# Altium schematic sheet MCU_EFM32.SchDoc
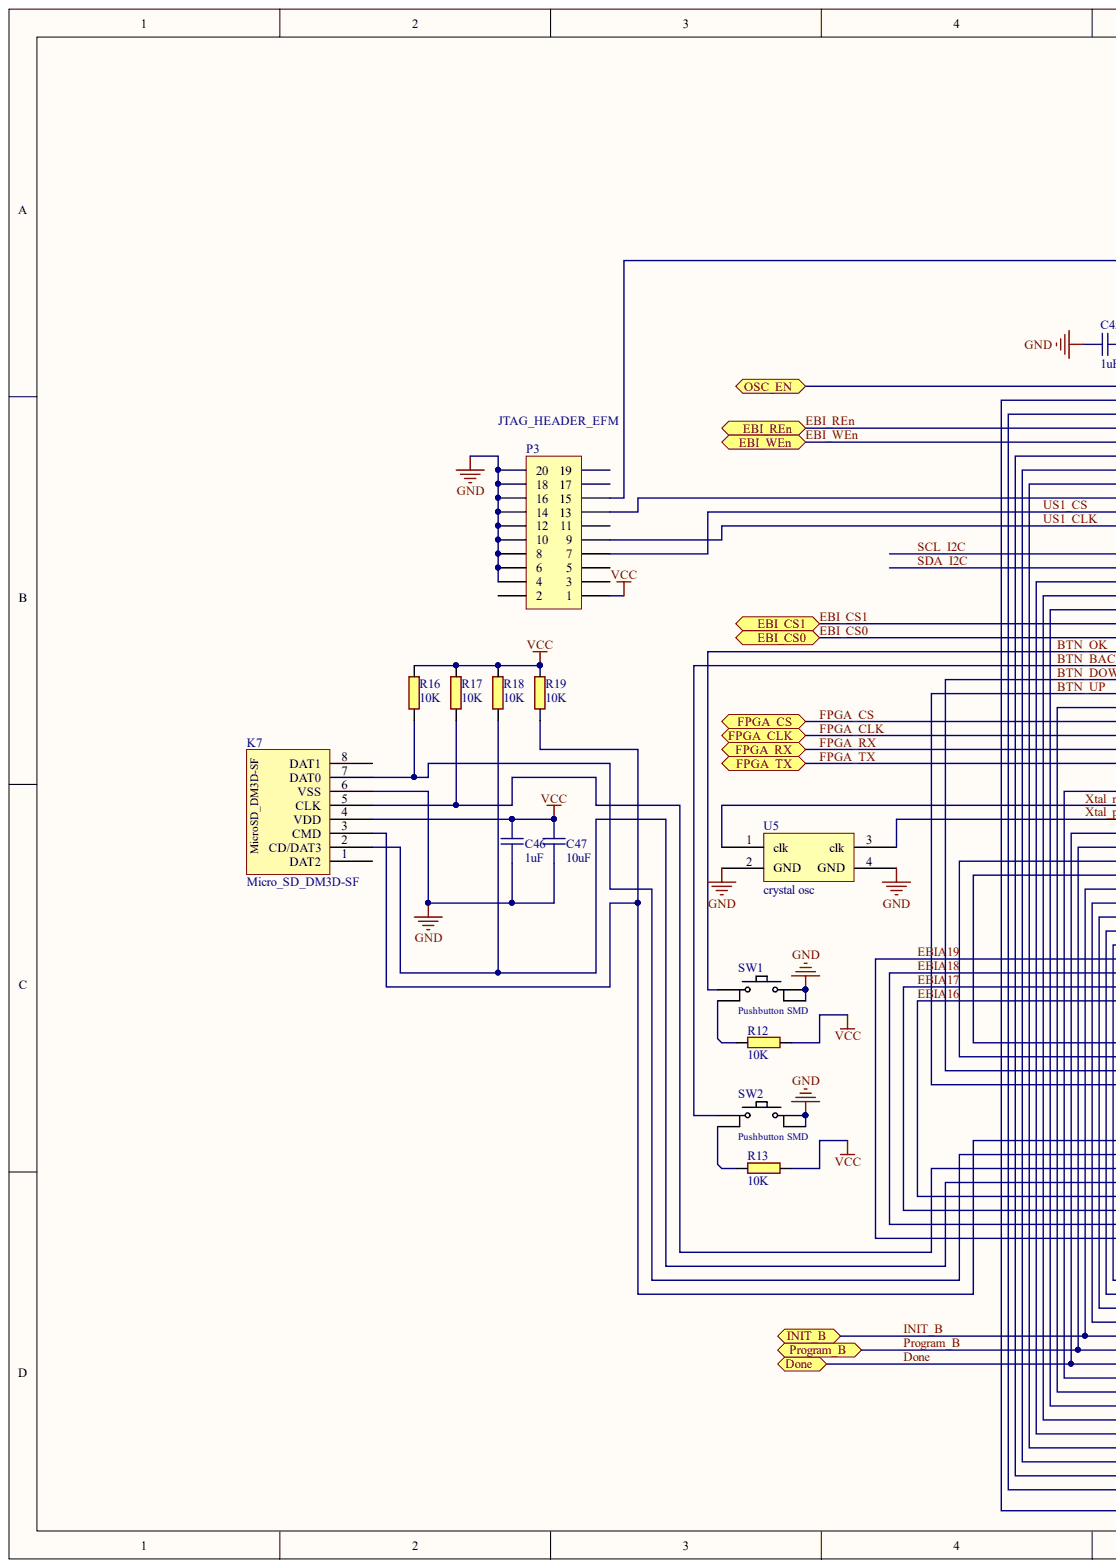
[diagram p0: MCU_EFM32.SchDoc, left]
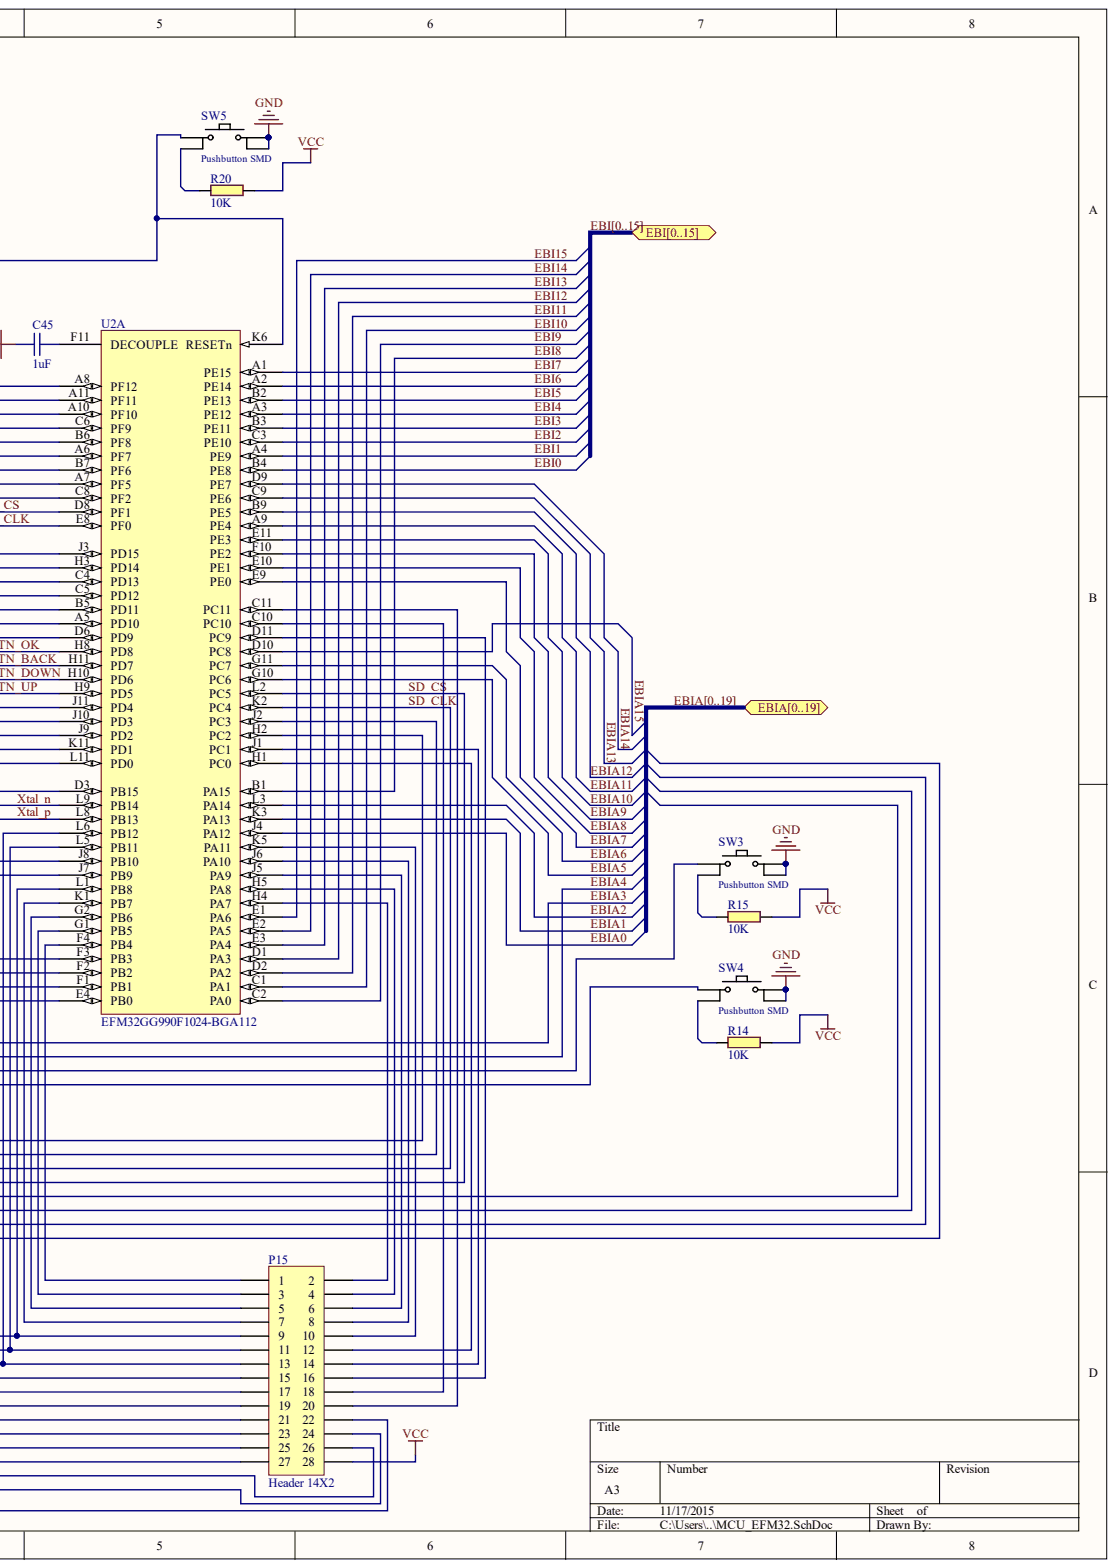
[diagram p1: MCU_EFM32.SchDoc, right]

## Parts
C45  1uF  [CAPC0603(1608)55_N]
C46  1uF  [CAPC0603(1608)55_N]
C47  10uF  [CAPC0603(1608)55_N]
K7  Micro_SD_DM3D-SF  [DM3D-SF]
P3  JTAG_HEADER_EFM  [HDR2X10]
P15  Header 14X2  [HDR2X14]
R12  10K  [RESC0603(1608)_N]
R13  10K  [RESC0603(1608)_N]
R14  10K  [RESC0603(1608)_N]
R15  10K  [RESC0603(1608)_N]
R16  10K  [RESC0603(1608)_N]
R17  10K  [RESC0603(1608)_N]
R18  10K  [RESC0603(1608)_N]
R19  10K  [RESC0603(1608)_N]
R20  10K  [RESC0603(1608)_N]
SW1  Pushbutton SMD  [SOIC254P500X160-4]
SW2  Pushbutton SMD  [SOIC254P500X160-4]
SW3  Pushbutton SMD  [SOIC254P500X160-4]
SW4  Pushbutton SMD  [SOIC254P500X160-4]
SW5  Pushbutton SMD  [SOIC254P500X160-4]
U2  EFM32GG990F1024-BGA112  [RESC0603(1608)_N]  (part A)
U5  crystal osc  [Crystall oscillator]

## Ports
DONE, EBI0, EBI1, EBI2, EBI3, EBI4, EBI5, EBI6, EBI7, EBI8, EBI9, EBI10, EBI11, EBI12, EBI13, EBI14, EBI15, EBI[0..15], EBI_CS0, EBI_CS1, EBI_REN, EBI_WEN, EBIA0, EBIA1, EBIA2, EBIA3, EBIA4, EBIA5, EBIA6, EBIA7, EBIA8, EBIA9, EBIA10, EBIA11, EBIA12, EBIA13, EBIA14, EBIA15, EBIA16, EBIA17, EBIA18, EBIA19, EBIA[0..19], FPGA_CLK, FPGA_CS, FPGA_RX, FPGA_TX, INIT_B, OSC_EN, PROGRAM_B

## Nets
GND: C45.1 C46.1 C47.1 K7.6(VSS) P3.4 P3.6 P3.8 P3.10 P3.12 P3.14 P3.16 P3.18 P3.20 SW1.3 SW1.4 SW2.3 SW2.4 SW3.3 SW3.4 SW4.3 SW4.4 SW5.3 SW5.4 U5.2(GND) U5.4(GND)
VCC: C46.2 C47.2 K7.4(VDD) P3.1 P15.28 R12.2 R13.2 R14.2 R15.2 R16.2 R17.2 R18.2 R19.2 R20.2
BTN_BACK: SW2.1 U2.H11(PD7)
BTN_DOWN: SW3.1 U2.H10(PD6)
BTN_OK: SW1.1 U2.H8(PD8)
BTN_UP: SW4.1 U2.H9(PD5)
DONE: P15.13 U2.L6(PB12) ; port DONE
EBI0: U2.B4(PE8) ; port EBI[0..15]  [also labelled EBI]
EBI1: U2.A4(PE9) ; port EBI[0..15]  [also labelled EBI]
EBI2: U2.C3(PE10) ; port EBI[0..15]  [also labelled EBI]
EBI3: U2.B3(PE11) ; port EBI[0..15]  [also labelled EBI]
EBI4: U2.A3(PE12) ; port EBI[0..15]  [also labelled EBI]
EBI5: U2.B2(PE13) ; port EBI[0..15]  [also labelled EBI]
EBI6: U2.A2(PE14) ; port EBI[0..15]  [also labelled EBI]
EBI7: U2.A1(PE15) ; port EBI[0..15]  [also labelled EBI]
EBI8: U2.B1(PA15) ; port EBI[0..15]  [also labelled EBI]
EBI9: U2.C2(PA0) ; port EBI[0..15]  [also labelled EBI]
EBI10: U2.C1(PA1) ; port EBI[0..15]  [also labelled EBI]
EBI11: U2.D2(PA2) ; port EBI[0..15]  [also labelled EBI]
EBI12: U2.D1(PA3) ; port EBI[0..15]  [also labelled EBI]
EBI13: U2.E3(PA4) ; port EBI[0..15]  [also labelled EBI]
EBI14: U2.E2(PA5) ; port EBI[0..15]  [also labelled EBI]
EBI15: U2.E1(PA6) ; port EBI[0..15]  [also labelled EBI]
EBI_CS0: U2.D6(PD9) ; port EBI_CS0
EBI_CS1: U2.A5(PD10) ; port EBI_CS1
EBI_REN: U2.C6(PF9) ; port EBI_REN
EBI_WEN: U2.B6(PF8) ; port EBI_WEN
EBIA0: U2.J4(PA12) ; port EBIA[0..19]  [also labelled EBIA]
EBIA1: U2.K3(PA13) ; port EBIA[0..19]  [also labelled EBIA]
EBIA2: U2.L3(PA14) ; port EBIA[0..19]  [also labelled EBIA]
EBIA3: U2.J7(PB9) ; port EBIA[0..19]  [also labelled EBIA]
EBIA4: U2.J8(PB10) ; port EBIA[0..19]  [also labelled EBIA]
EBIA5: U2.G10(PC6) ; port EBIA[0..19]  [also labelled EBIA]
EBIA6: U2.G11(PC7) ; port EBIA[0..19]  [also labelled EBIA]
EBIA7: U2.E9(PE0) ; port EBIA[0..19]  [also labelled EBIA]
EBIA8: U2.E10(PE1) ; port EBIA[0..19]  [also labelled EBIA]
EBIA9: U2.F10(PE2) ; port EBIA[0..19]  [also labelled EBIA]
EBIA10: U2.E11(PE3) ; port EBIA[0..19]  [also labelled EBIA]
EBIA11: U2.A9(PE4) ; port EBIA[0..19]  [also labelled EBIA]
EBIA12: U2.B9(PE5) ; port EBIA[0..19]  [also labelled EBIA]
EBIA13: U2.C9(PE6) ; port EBIA[0..19]  [also labelled EBIA]
EBIA14: U2.D9(PE7) ; port EBIA[0..19]  [also labelled EBIA]
EBIA15: U2.D10(PC8) ; port EBIA[0..19]  [also labelled EBIA]
EBIA16: U2.E4(PB0) ; port EBIA[0..19]  [also labelled EBIA]
EBIA17: U2.F1(PB1) ; port EBIA[0..19]  [also labelled EBIA]
EBIA18: U2.F2(PB2) ; port EBIA[0..19]  [also labelled EBIA]
EBIA19: U2.F3(PB3) ; port EBIA[0..19]  [also labelled EBIA]
FPGA_CLK: U2.J9(PD2) ; port FPGA_CLK
FPGA_CS: U2.J10(PD3) ; port FPGA_CS
FPGA_RX: U2.K11(PD1) ; port FPGA_RX
FPGA_TX: U2.L11(PD0) ; port FPGA_TX
INIT_B: P15.9 U2.L1(PB8) ; port INIT_B
OSC_EN: U2.A8(PF12) ; port OSC_EN
PROGRAM_B: P15.11 U2.L5(PB11) ; port PROGRAM_B
SCL_I2C: U2.J3(PD15)
SD_CLK: K7.5(CLK) R17.1 U2.K2(PC4)
SD_CS: K7.2(CD/DAT3) R18.1 U2.L2(PC5)
SDA_I2C: U2.H3(PD14)
US1_CLK: P3.9 U2.E8(PF0)
US1_CS: P3.7 U2.D8(PF1)
XTAL_N: U2.L9(PB14) U5.1(clk)
XTAL_P: U2.L8(PB13) U5.3(clk)
NetC45_2: C45.2 U2.F11(DECOUPLE)
NetK7_3: K7.3(CMD) R19.1 U2.H2(PC2)
NetK7_7: K7.7(DAT0) R16.1 U2.J2(PC3)
NetP3_13: P3.13 U2.C8(PF2)
NetP3_15: P3.15 SW5.1 U2.K6(RESETn)
NetP15_1: P15.1 U2.F4(PB4)
NetP15_2: P15.2 U2.H4(PA7)
NetP15_3: P15.3 U2.G1(PB5)
NetP15_4: P15.4 U2.H5(PA8)
NetP15_5: P15.5 U2.G2(PB6)
NetP15_6: P15.6 U2.J5(PA9)
NetP15_7: P15.7 U2.K1(PB7)
NetP15_8: P15.8 U2.J6(PA10)
NetP15_10: P15.10 U2.K5(PA11)
NetP15_12: P15.12 U2.H1(PC0)
NetP15_14: P15.14 U2.J1(PC1)
NetP15_15: P15.15 U2.D3(PB15)
NetP15_16: P15.16 U2.D11(PC9)
NetP15_17: P15.17 U2.J11(PD4)
NetP15_18: P15.18 U2.C10(PC10)
NetP15_19: P15.19 U2.B5(PD11)
NetP15_20: P15.20 U2.C11(PC11)
NetP15_21: P15.21 U2.C5(PD12)
NetP15_22: P15.22 U2.A11(PF11)
NetP15_23: P15.23 U2.C4(PD13)
NetP15_24: P15.24 U2.A10(PF10)
NetP15_25: P15.25 U2.A7(PF5)
NetP15_26: P15.26 U2.A6(PF7)
NetP15_27: P15.27 U2.B7(PF6)
NetR12_1: R12.1 SW1.2
NetR13_1: R13.1 SW2.2
NetR14_1: R14.1 SW4.2
NetR15_1: R15.1 SW3.2
NetR20_1: R20.1 SW5.2

Not connected: K7 1(DAT2) 8(DAT1); P3 2 3 5 11 17 19

## Library data of the parts
C45-C47 = 2.2uF(0603): 2.2uF 0603 chip X7R 6.3V; Database Table Name: altium_Kondensatorer; id: 2996; leverandor: Farnell; leverandor_varenr: 2320810; nokkelord: Kondensator, capacitor; pakke_opprettet_av: 815; pakketype: 93; pris: 1; produsent: Multikomp; Component Kind: Standard; Library Name: OVLib.SVNDbLib; navn: 2.2uF(0603); pakke_opprettet: 2/18/2015 2:18:13 PM; symbol_opprettet: 11/14/2014 8:19:34 PM; symbol_opprettet_av: 815
P3 = Header 10X2: Header, 10-Pin, Dual row; Component Kind: Standard; LatestRevisionDate: 17-Jul-2002; LatestRevisionNote: Re-released for DXP Platform.; Library Name: Miscellaneous Connectors.IntLib; Signal Integrity: Connector; Publisher: Altium Limited
P15 = Header 14X2: Header, 14-Pin, Dual row; Component Kind: Standard; LatestRevisionDate: 17-Jul-2002; LatestRevisionNote: Re-released for DXP Platform.; Library Name: Miscellaneous Connectors.IntLib; Signal Integrity: Connector; Publisher: Altium Limited
R12-R20 = 2448: 330R 0603 0.1W 1% Thick film resistor; antall: 100; Database Table Name: altium_Motstander; dybde: 42; hylle: 6; kolonne: 0; lager_type: Fremlager; navn: 330R(0603); nokkelord: Resistor Motstand; pakke_opprettet_av: 815; pakketype: 93; rad: -1; rom: OV; symbol_opprettet_av: oystesm; Component Kind: Standard; id: 2448; Library Name: OVLib.SVNDbLib; pakke_opprettet: 7/8/2014 9:15:30 PM; pris: 0; symbol_opprettet: 7/8/2014 9:16:33 PM
SW1-SW5 = Pushbutton SMD: Momentary tactile pushbutton SMD; antall: 100; Database Table Name: altium; dybde: 2; hylle: 7; id: 2413; kolonne: 3; lager_type: Fremlager; nokkelord: smd, tactile, pushbutton, knapp, smd; pakke_opprettet_av: 815; pakketype: 101; rom: OV; Component Kind: Standard; Library Name: OVLib.SVNDbLib; navn: Pushbutton SMD; pakke_opprettet: 11/14/2014 10:12:31 PM; pris: 2; rad: 3; symbol_opprettet: 11/14/2014 9:57:30 PM; symbol_opprettet_av: 815
U2 = CMP-0270-00024-1: EFM32 Giant Gecko, M3 ARM Cortex CPU, 1024 KB Flash, 128 KB RAM, 1.85 - 3.8 V Supply, 48 MHz Max Speed, -40 to 85 degC, 112-Pin BGA, RoHS Compliant; Flash (KB): 1024; GPIO: 87; LCD: 8x34; LESENSE: Y; Max Speed (MHz): 48; OPAMPS: 3; RAM (KB): 128; ARM Cortex CPU: M3; Component Kind: Standard; ComponentLink1Description: Manufacturer URL; ComponentLink1URL: http://www.energymicro.com/; ComponentLink2Description: Datasheet; ComponentLink2URL: http://cdn.energymicro.com/dl/devices/pdf/d0046_efm32gg990_datasheet.pdf; ComponentLink3Description: Package Specification; ComponentLink3URL: http://cdn.energymicro.com/dl/devices/pdf/d0007_efm32g290_datasheet.pdf; DatasheetVersion: Rev 1.00; Generic Part Number: EFM32GG990; Library Name: Altium Content Vault; Mounting Technology: Surface Mount; PackageDescription: 112-Pin Ball Grid Array, Body 10 x 10 mm, Pitch 0.8 mm; PackageReference: BGA112; PackageVersion: Rev. 97SPP01315A_X03_06Jun11; PartNumber: EFM32GG990F1024-BGA112; Subcategory: EFM32 Giant Gecko; Supply Voltage (V): 1.85 - 3.8; USB: Y
K7 = Micro_SD_DM3D-SF: Component Kind: Standard; Library Name: Components.SchLib
U5 = crystal osc: Component Kind: Standard; Library Name: Components.SchLib
